# Altium schematic sheet PowerManagement.SchDoc
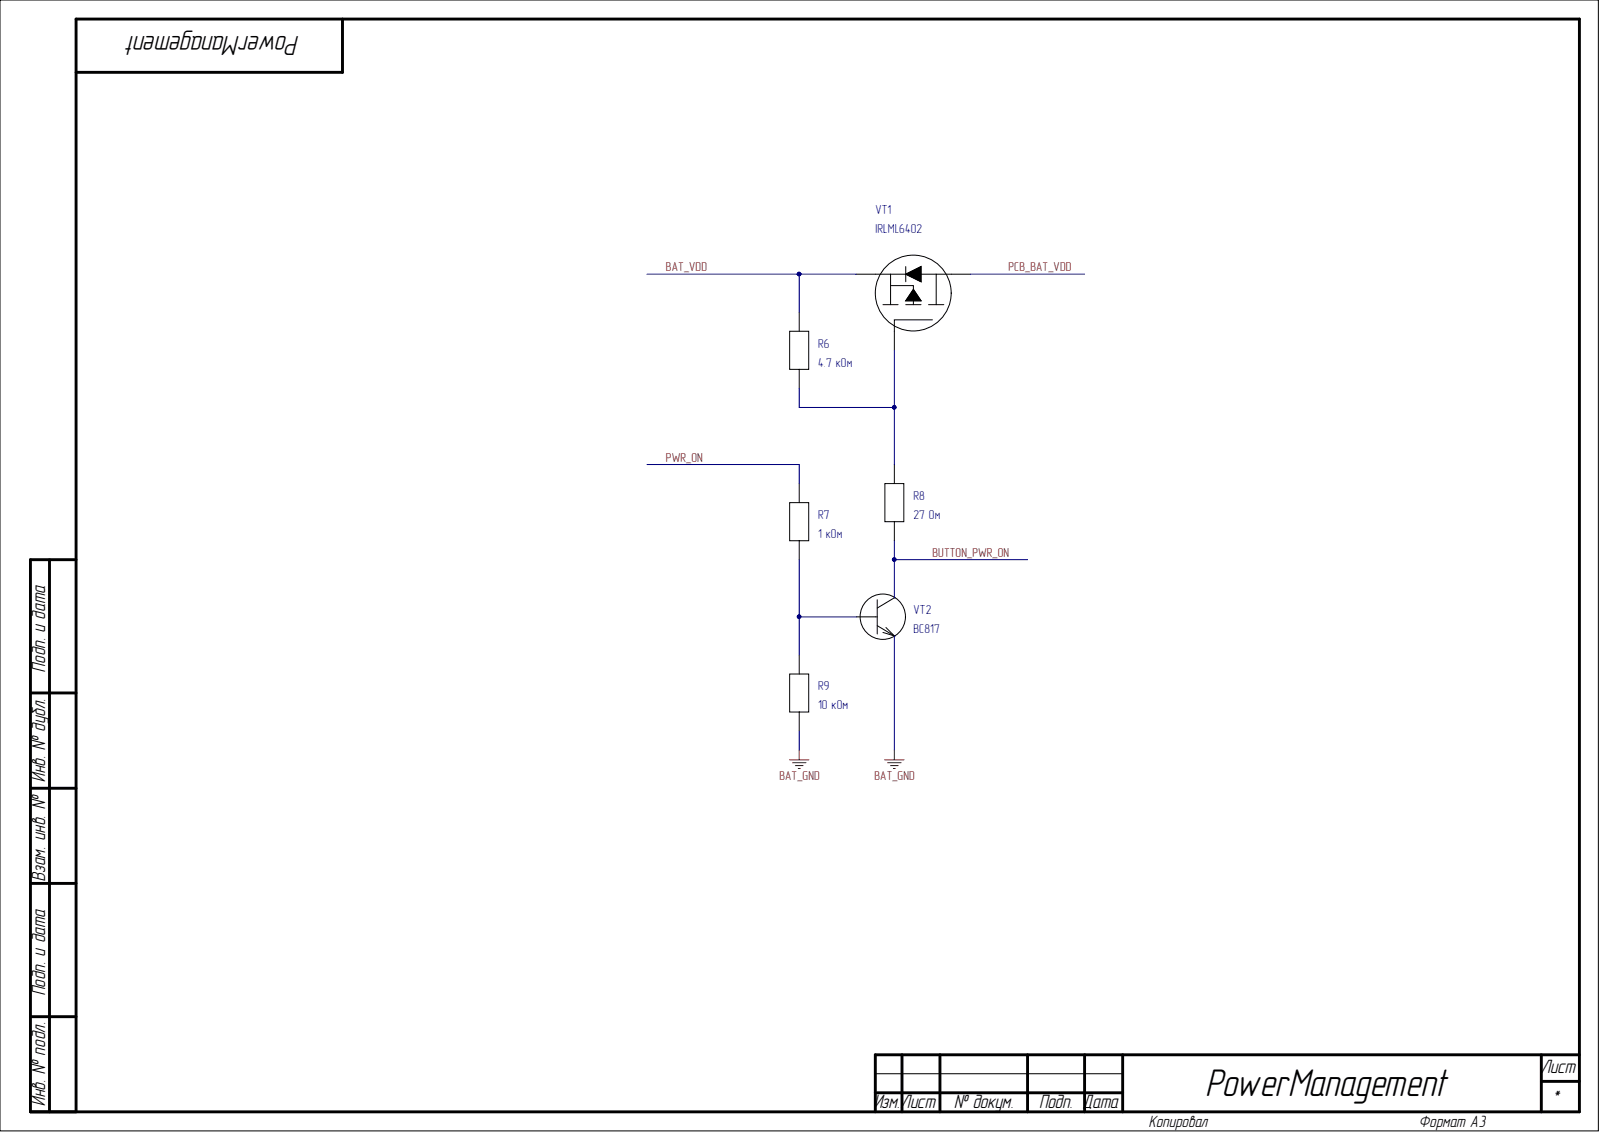
[diagram p0: PowerManagement.SchDoc]

## Parts
R6  4.7 кОм  [RES 0402]
R7  1 кОм  [RES 0402]
R8  27 Ом  [RES 0402]
R9  10 кОм  [RES 0402]
VT1  IRLML6402  [SOT-23]
VT2  BC817  [SOT-23]

## Nets
BAT_GND: R9.1 VT2.2
BAT_VDD: R6.2 VT1.2
BUTTON_PWR_ON: R8.1 VT2.3
PCB_BAT_VDD: VT1.3
PWR_ON: R7.2
NetR6_1: R6.1 R8.2 VT1.1
NetR7_1: R7.1 R9.2 VT2.1

## Library data of the parts
R6-R9 = RES 0402: Component Kind: Standard; Library Name: my_lib.SchLib
VT2 = BC817: NPN general-purpose transistor; Manufacturer: NXP; Base-emitter on voltage: 1,2 В; Collector-base сapacitance: 3 пФ; Collector-emitter saturation voltage: 0,7 В; Complement: BC807; fT: 100 МГц; hFE: 160 ... 600; Max collector-base voltage: 50 В; Max collector-emitter voltage: 45 В; Max collector current: 0,5 А; Max collector peak current: 1 А; Max emitter-base voltage: 5 В; Power dissitipation: 0,25 Вт; Specitication: ЭКБ ИП; Structure: n-p-n; Type: Транзистор биполярный; Component Kind: Standard; Library Name: my_lib.SchLib; Temperature range: -65 ... 150 °C
VT1 = IRLML6402: Component Kind: Standard; Library Name: my_lib.SchLib
